# Altium schematic sheet CW308T_AVR_CONN.SchDoc(CW308T_AVR_CONN)
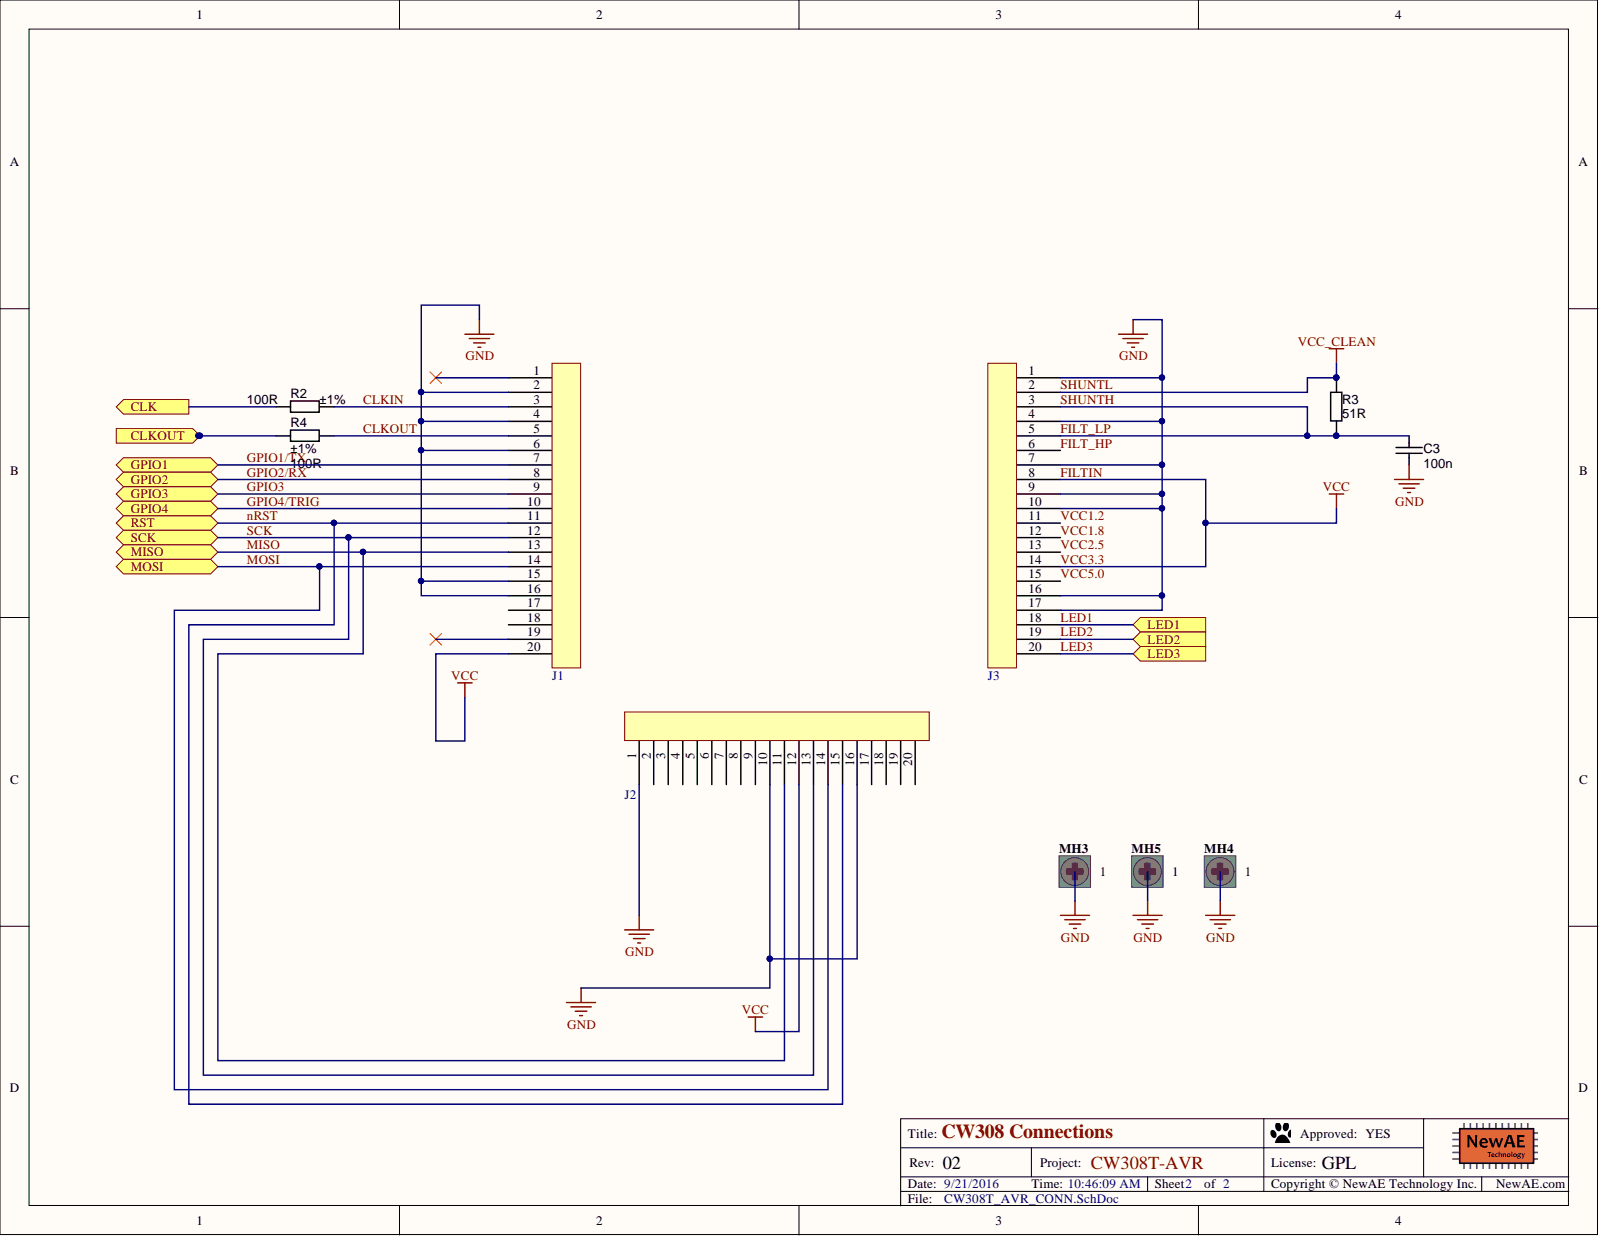
[diagram p0: CW308T_AVR_CONN.SchDoc]

## Parts
C3  value 100n  [CAPC1608X90N]  (hidden comment: approved sub OK)
J1  20-Pin Header  [801-87-020-10-001101]  (value not drawn)
J2  20-Pin Header  [801-87-020-10-001101]  (value not drawn)
J3  20-Pin Header  [801-87-020-10-001101]  (value not drawn)
MH3  Mounting-Hole-RND-Altium  [Mounting-Hole-RND-Altium]  (value not drawn)  (no BOM)
MH4  Mounting-Hole-RND-Altium  [Mounting-Hole-RND-Altium]  (value not drawn)  (no BOM)
MH5  Mounting-Hole-RND-Altium  [Mounting-Hole-RND-Altium]  (value not drawn)  (no BOM)
R2  value 100R  Tolerance: ±1%  [RESC1608X55N]  (hidden comment: Thick Film)
R3  value 51R  [RESC2012X70N]  (hidden comment: Thick Film)
R4  value 100R  Tolerance: ±1%  [RESC1608X55N]  (hidden comment: Thick Film)

## Ports
CLK, CLKOUT, GPIO1, GPIO2, GPIO3, GPIO4, LED1, LED2, LED3, MISO, MOSI, RST, SCK

## Nets
GND: C3.2 J1.2 J1.4 J1.6 J1.15 J1.16 J2.1 J2.10 J2.16 J3.1 J3.4 J3.7 J3.9 J3.10 J3.16 J3.17 MH3.1 MH4.1 MH5.1
CLK: R2.1 ; port CLK
CLKIN: J1.3 R2.2
CLKOUT: J1.5 R4.2
FILT_HP: J3.6
FILT_LP: C3.1 J3.3 J3.5 R3.2
FILTIN: J1.20 J2.12 J3.8 J3.14
GPIO1/TX: J1.7 ; port GPIO1
GPIO2/RX: J1.8 ; port GPIO2
GPIO3: J1.9 ; port GPIO3
GPIO4/TRIG: J1.10 ; port GPIO4
LED1: J3.18 ; port LED1
LED2: J3.19 ; port LED2
LED3: J3.20 ; port LED3
MISO: J1.13 J2.11 ; port MISO
MOSI: J1.14 J2.14 ; port MOSI
NRST: J1.11 J2.15 ; port RST
SCK: J1.12 J2.13 ; port SCK
SHUNTL: J3.2 R3.1
VCC1.2: J3.11
VCC1.8: J3.12
VCC2.5: J3.13
VCC5.0: J3.15
NetR4_1: R4.1 ; port CLKOUT

Not connected: J1 1 17 18 19; J2 2 3 4 5 6 7 8 9 17 18 19 20

## Library data of the parts
C3 = CAPC_100n_0603_X7R_50V_10%: Capacitor SMD Cer 100nF 10% 50V X7R 0603; Manufacturer 1: AVX Corporation; Manufacturer Part Number 1: 06035C104KAT2A; Material: ceramic; Ratings: 50V; X7R; SCDType: spec; Component Kind: Standard; ComponentLink1Description: http://www.avx.com/docs/Catalogs/cx7r.pdf; ComponentLink1URL: http://www.avx.com/docs/Catalogs/cx7r.pdf; ComponentLink2Description: http://product.tdk.com/capacitor/mlcc/en/documents/mlcc_commercial_general_en.pdf; ComponentLink2URL: http://product.tdk.com/capacitor/mlcc/en/documents/mlcc_commercial_general_en.pdf; Library Name: PBL - Capacitors.SCHLIB; Manufacturer 2: TDK Corporation; Manufacturer Part Number 2: C1608X7R1H104K; Package / Case: 0603 (1608 Metric); Supplier 1: Digi-Key; Supplier 2: Digi-Key; Supplier Part Number 1: 478-5052-1-ND; Supplier Part Number 2: 445-1314-1-ND; Tolerance: ±10%
J1-J3 = 801-87-020-10-001101: 1x20 Header, Machined; Manufacture 1: Preci-Dip SA; Component Kind: Standard; Library Name: NewAE_AltiumLib.SchLib; Manufacture Part Number 1: 801-87-020-10-001101; Supplier 1: Digi-Key; Supplier Part Number 1: 1212-1207-ND
MH3-MH5 = CMP-0001-00029-1: Mounting Hole, Altium, 4.4mm pad, 3.4mm plated hole; ClassName: Mounting Hole; Library Name: Altium Content Vault; Publisher: Altium
R2 R4 = RES_100R_0603_1%: Resistor SMD chip 100 Ohm 0.1W 1% 0603; Manufacturer 1: Yageo; Manufacturer Part Number 1: RC0603FR-07100RL; Ratings: 1/10 W; SCDType: spec; Component Kind: Standard; ComponentLink1Description: http://industrial.panasonic.com/www-cgi/jvcr13pz.cgi?E+PZ+3+AOA0002+ERJ3EKF1000V+7+WW; ComponentLink1URL: http://industrial.panasonic.com/www-cgi/jvcr13pz.cgi?E+PZ+3+AOA0002+ERJ3EKF1000V+7+WW; Library Name: PBL - Resistors.SCHLIB; Manufacturer 2: Panasonic - ECG; Manufacturer Part Number 2: ERJ-3EKF1000V; Material: Thick Film; Package / Case: 0603 (1608 Metric); Supplier 1: Digi-Key; Supplier 2: Digi-Key; Supplier Part Number 1: 311-100HRCT-ND; Supplier Part Number 2: P100HTR-ND
R3 = RES_15R_0805_5%: Resistor SMD chip 15 Ohm 0.125W 5% 0805; Manufacturer 1: Yageo; Manufacturer Part Number 1: RC0805JR-0715RL; Ratings: 1/8W; SCDType: spec; Component Kind: Standard; ComponentLink1Description: http://media.digikey.com/pdf/Data%20Sheets/Yageo%20PDFs/RC0805%20Pb%20Free.pdf; ComponentLink1URL: http://media.digikey.com/pdf/Data%20Sheets/Yageo%20PDFs/RC0805%20Pb%20Free.pdf; ComponentLink2Description: http://industrial.panasonic.com/www-cgi/jvcr13pz.cgi?E+PZ+3+AOA0001+ERJ6GEYJ150V+7+WW; ComponentLink2URL: http://industrial.panasonic.com/www-cgi/jvcr13pz.cgi?E+PZ+3+AOA0001+ERJ6GEYJ150V+7+WW; ComponentLink3Description: http://www.seielect.com/Catalog/SEI-RMCF_RMCP.pdf; ComponentLink3URL: http://www.seielect.com/Catalog/SEI-RMCF_RMCP.pdf; ComponentLink4Description: http://www.vishay.com/doc?20035; ComponentLink4URL: http://www.vishay.com/doc?20035; Library Name: PBL - Resistors.SCHLIB; Manufacturer 2: Panasonic Electronic Components; Manufacturer 3: Stackpole Electronics Inc; Manufacturer 4: Vishay Dale; Manufacturer Part Number 2: ERJ-6GEYJ150V; Manufacturer Part Number 3: RMCF0805JT15R0; Manufacturer Part Number 4: CRCW080515R0JNEA; Package / Case: 0805 (2012 metric); RoHS 1: RoHS Compliant; RoHS 2: RoHS Compliant; RoHS 3: RoHS Compliant; RoHS 4: RoHS Compliant; Supplier 1: Digi-Key; Supplier 2: Digi-Key; Supplier 3: Digi-Key; Supplier 4: Digi-Key; Supplier Part Number 1: 311-15ARCT-ND; Supplier Part Number 2: P15ACT-ND; Supplier Part Number 3: RMCF0805JT15R0CT-ND; Supplier Part Number 4: 541-15ACT-ND; Tolerance: ±5%
